# Altium schematic sheet gps.SchDoc(gps)
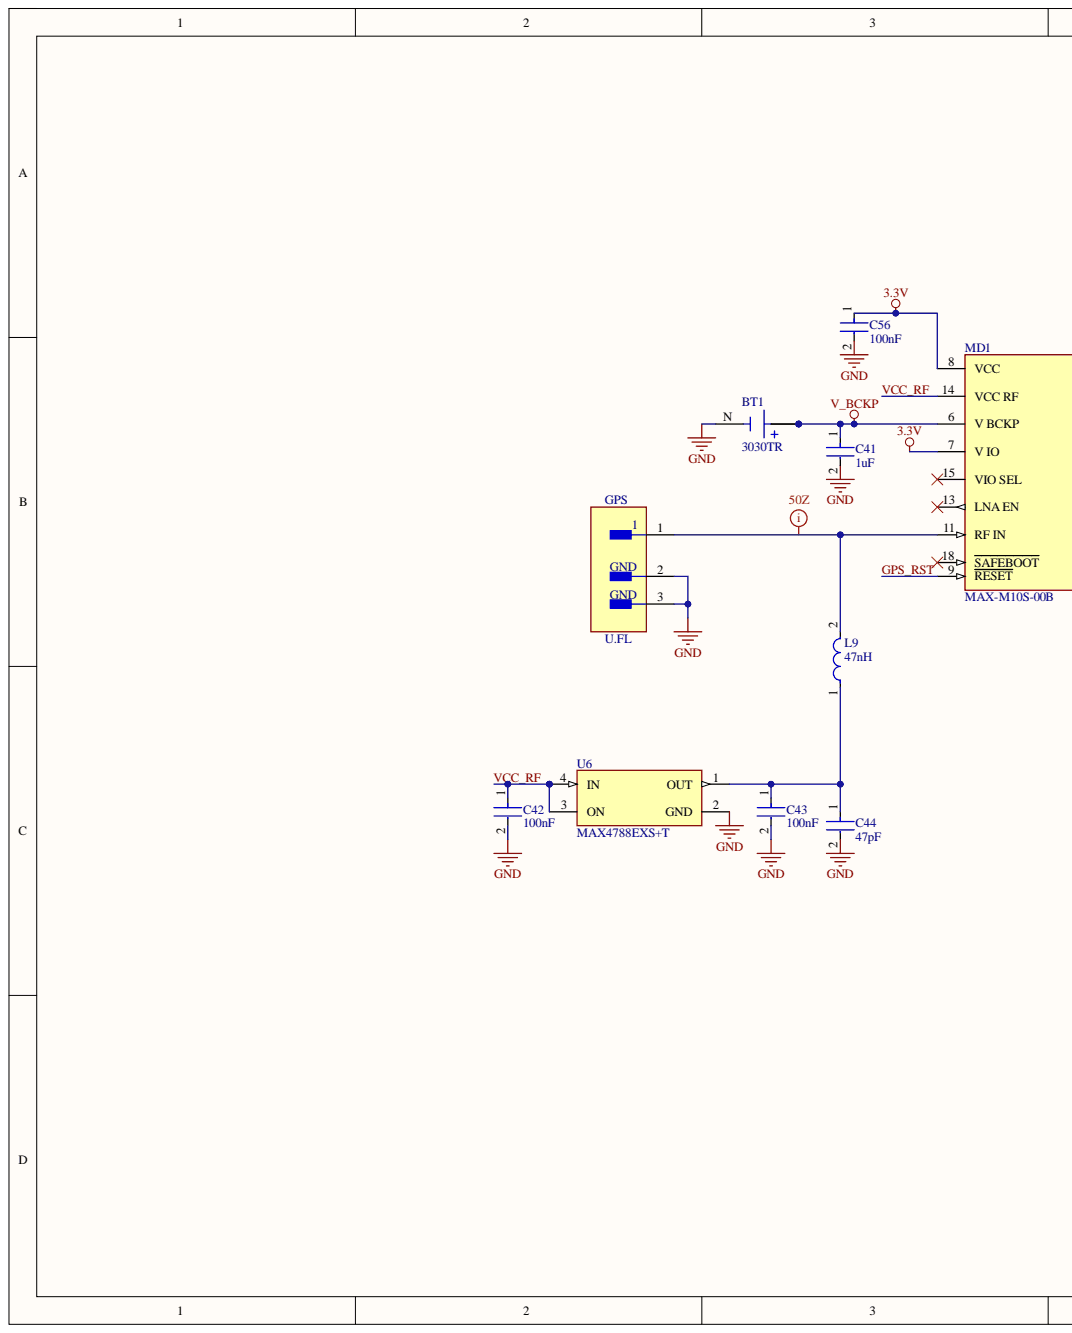
[diagram p0: gps.SchDoc, left]
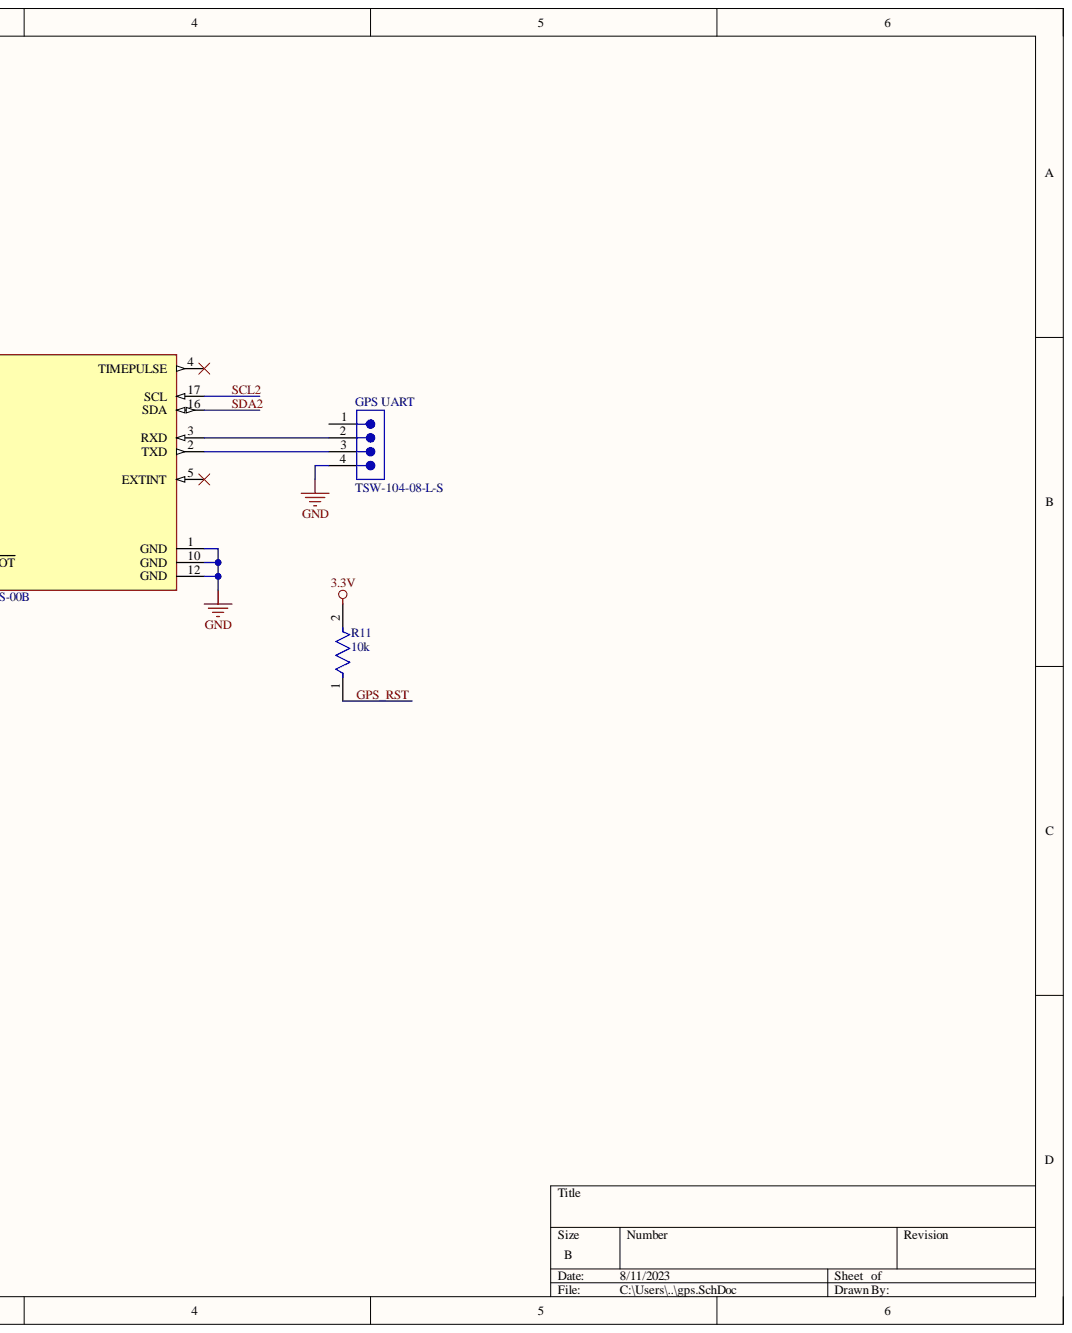
[diagram p1: gps.SchDoc, right]

## Parts
BT1  3030TR  [BAT_3030TR]
C41  1uF  [FP-C0805C105M5RACTU-MFG]
C42  100nF  [FP-C0603C-CF-MFG]
C43  100nF  [FP-C0603C-CF-MFG]
C44  47pF  [FP-0603-L_1_6_0_15-W_0_81-MFG]
C56  100nF  [FP-C0603C-CF-MFG]
GPS  U.FL  [FP-1909763-1-MFG]
GPS_UART  TSW-104-08-L-S  [SMTC-TSW-104-08-X-S]
L9  47nH  [FP-LQW18AN_00-MFG]
MD1  MAX-M10S-00B  [FP-MAX-M10S-00B-MFG]
R11  10k  [FP-RT0603-MFG]
U6  MAX4788EXS+T  [FP-X4-1-MFG]

## Nets
3.3V: C56.1 MD1.7(V_IO) MD1.8(VCC) R11.2
GND: BT1.N C41.2 C42.2 C43.2 C44.2 C56.2 GPS.2 GPS.3 GPS_UART.4 MD1.1(GND) MD1.10(GND) MD1.12(GND) U6.2(GND)
V_BCKP: BT1.P1 BT1.P2 C41.1 MD1.6(V_BCKP)
GPS_RST: MD1.9(RESET) R11.1
SCL2: MD1.17(SCL)
SDA2: MD1.16(SDA)
VCC_RF: C42.1 MD1.14(VCC_RF) U6.3(ON) U6.4(IN)
NetC43_1: C43.1 C44.1 L9.1 U6.1(OUT)
NetGPS UART_2: GPS_UART.2 MD1.3(RXD)
NetGPS UART_3: GPS_UART.3 MD1.2(TXD)
NetGPS_1: GPS.1 L9.2 MD1.11(RF_IN)

Not connected: GPS_UART 1; MD1 4(TIMEPULSE) 5(EXTINT) 13(LNA_EN) 15(VIO_SEL) 18(SAFEBOOT)

## Library data of the parts
BT1 = CMP-025-000000-1: Availability: Unavailable; Component Kind: Standard; Component Type: Standard; Design Item ID: 3030TR; MP: 3030TR; Package: None; Pin Count: 3; Price: None; Source: 3030TR.SchLib; Library Name: Purdue Space Program - High Altitude
C41 = CMP-2007-01203-2: CAP CER 1UF 50V X7R 0805; Dielectric Material: Ceramic; Dissipation Factor: 3%; Tolerance: 20%; Capacitance: 1uF; Case Code (Imperial): 0805; Case Code (Metric): 2012; Case/Package: 0805; Component Kind: Standard; Dielectric: X7R; Length: 0.079inch; Library Name: Altium Content Vault; Max Operating Temperature: 125°C; Min Operating Temperature: -55°C; Mount: Surface Mount; Package Quantity: 1; Packaging: Tape and Reel; RoHS Compliant: Yes; Series: C; Termination: SMD/SMT; Thickness: 0.055inch; Voltage Rating: 50V; Voltage Rating (DC): 50V; Width: 0.049inch
C42 C43 C56 = CMP-2006-03061-2: CAP CER 0.1UF 50V X7R 0603; Manufacturer: KEMET; Manufacturer Part Number: C0603C104K5RACTU; Automotive Grade: Grade 1; Capacitance: 0.10 μF; Material: Ceramic; Temperature Coefficient: 30ppm/°C; Tolerance: 0.1; Value: 0.10 μF; Automotive: Yes; Category: Cap; Component Kind: Standard; ComponentLink2Description: Datasheet; ComponentLink2URL: https://api.kemet.com/component-edge/download/datasheet/C0603C100J5GACAUTO.pdf; Device Class L1: Passive Components; Device Class L2: Capacitors; Device Class L3: Ceramic Capacitors; Digikey Description: CAP CER 0.1UF 50V X7R 0603; Height: 0.87mm; Lead Free: Yes; Library Name: Altium Content Vault; Octopart: https://octopart.com/c0603c104k5ractu-kemet-139244; Package: C0603C-CF; RoHS: TRUE; Temperature: -55°C to +125°C; Temperature Characteristic: X7R; Temperature Range High: +125°C; Temperature Range Low: -55°C; Voltage Rating: 50 V
C44 = CMP-2006-04316-2: General Purpose Ceramic Capacitor, 0603, 47pF, 5%, NP0, 30ppm/°C, 50V; Dielectric: C0G; Capacitance: 47pF; Case Code (Imperial): 0603; Case Code (Metric): 1608; Case/Package: 0603; Component Kind: Standard; Contact Plating: Tin; Depth: 0.81mm; Height: 0.9mm; Lead Free: Lead Free; Length: 1.6mm; Library Name: Altium Content Vault; Max Operating Temperature: 125°C; Min Operating Temperature: -55°C; Mount: Surface Mount; Number of Pins: 2; Package Quantity: 1; Packaging: Tape and Reel; Radiation Hardening: No; REACH SVHC: No SVHC; RoHS Compliant: Yes; Temperature Coefficient: 30ppm/°C; Termination: SMD/SMT; Thickness: 0.035inch; Tolerance: 5%; Voltage: 50V; Voltage Rating: 50V; Voltage Rating (DC): 50V; Width: 0.032inch
GPS = CMP-2000-07505-2: CONN UMCC JACK STR 50OHM SMD; Body Material: Polymer; Body Plating: Gold; Connector System: Cable-to-Board; Frequency: 6GHz; Gender: Female; Impedance: 50R; Insertion Loss: 0.24dB; Mating Cycles: 30; Number of Ports: 1; Sealable: No (Without); Voltage Rating: 60V; Case/Package: SMD/SMT; Center Contact Plating: Gold; Component Kind: Standard; Connector Type: Jack; Contact Material: Copper Alloy; Contact Plating: Gold; Dielectric Material: Polymer; ELV: Compliant; Fastening Type: SNAP-ON; Housing Color: Beige; Insulation Material: Thermoplastic; Lead Free: Lead Free; Length: 3mm; Library Name: Altium Content Vault; Max Frequency: 6GHz; Max Operating Temperature: 194°C; Min Operating Temperature: -40°C; Mount: Panel; Number of Positions: 1; Orientation: Straight; Package Quantity: 1; Packaging: Tape and Reel; Radiation Hardening: No; RoHS Compliant: Yes; Series: -; Termination: SMD/SMT
GPS_UART = CMP-1024-00358-1: 0.025" SQ Post Header, Through-hole, Vertical, -55 to 125 degC, 2.54 mm Pitch, 4-Pin, Male, RoHS; Manufacturer: Samtec; Pitch: 2.54 mm; Component Kind: Standard; ComponentLink1Description: Manufacturer URL; ComponentLink1URL: http://www.samtec.com; ComponentLink2Description: Datasheet; ComponentLink2URL: http://www.samtec.com/documents/webfiles/pdf/TSW_TH.PDF; ComponentLink3Description: Package Specification; ComponentLink3URL: http://www.samtec.com/documents/webfiles/cpdf/TSW-XXX-XX-XXX-X-XX-XXX-MKT.pdf; DatasheetVersion: F-214; Library Name: Altium Content Vault; Mounting Technology: Through-hole; Orientation: Vertical; PackageDescription: .025[0.64] SQ. Post Terminal Strip Assembly; PackageReference: TSW-104-08-X-S; PackageVersion: DM, 8/1988; PartNumber: TSW-104-08-L-S; RoHS: True; Temperature Range: -55 to 125 degC
L9 = CMP-06042-007966-1: Wire Wound RF Inductor 47nH ±2% 380mA 0.29Ω 0603 (1608); Core Material: Non-Magnetic; Current: 340mA; DC Resistance (DCR): 290mR; Q Factor: 38; Self Resonant Frequency: 2.6GHz; Shielding: Unshielded; Test Frequency: 100MHz; Case Code (Imperial): 0603; Case Code (Metric): 1608; Case/Package: 0603; Component Kind: Standard; Current Rating: 380mA; DC Current: 380mA; Depth: 1mm; Height: 0.8mm; Height - Seated (Max): 0.039inch; Inductance: 47nH; Inductance Tolerance: 2%; Lead Free: Lead Free; Length: 1.6mm; Library Name: Altium Content Vault; Max DC Current: 380mA; Max Operating Temperature: 125°C; Military Standard: Not; Min Operating Temperature: -55°C; Mount: Surface Mount; Number of Pins: 2; Package Quantity: 4000; Packaging: Tape and Reel; Radiation Hardening: No; REACH SVHC: No SVHC; Resistor Type: Wirewound; Series Resistance: 290mR; Termination: SMD/SMT; Tolerance: 2%; Weight: 0.000106oz; Width: 0.8mm
MD1 = CMP-207130-000026-1: GNSS LCC RX, ROM, TCXO, LNA, SAW; Component Kind: Standard; Library Name: Altium Content Vault
R11 = CMP-2000-04517-2: RES SMD 10K OHM 0.1% 1/10W 0603; Manufacturer: Yageo; Manufacturer Part Number: RT0603BRE0710KL; Power Rating: 0.1W; Resistance: 10KΩ; Temperature Coefficient: 50 ppm/°C; Tolerance: 0.001; Value: 10KΩ; Voltage Rating: 75V; Automotive: No; Category: Res; Component Kind: Standard; ComponentLink2Description: Datasheet; ComponentLink2URL: http://www.yageo.com/documents/recent/PYu-RT_1-to-0.01_RoHS_L_9.pdf; Composition: Thin Film; Device Class L1: Passive Components; Device Class L2: Resistors; Device Class L3: Chip SMD Resistors; Digikey Description: RES SMD 10K OHM 0.1% 1/10W 0603; Footprint Url: http://www.yageo.com/exep/pages/download/literatures/PYu-R_Mount_10.pdf; Height: 0.55mm; Ipc Land Pattern Name: RESC16080X45; Lead Free: Yes; Library Name: Altium Content Vault; Mouser Description: Res Thin Film 0603 10K Ohm 0.1% 1/10W ±50ppm/°C Molded SMD SMD Paper T/R; Octopart: https://octopart.com/rt0603bre0710kl-yageo-1273959; Package: RT0603; RoHS: TRUE; Series: RT; Temperature: -55°C to +155°C; Temperature Range High: +155°C; Temperature Range Low: -55°C
U6 = CMP-05714-000107-1: IC SWITCH 1X1 SC70-4; Max Input Voltage: 5.5V; Max Output Current: 240mA; Max Power Dissipation: 245mW; Min Input Voltage: 2.3V; Number of Inputs: 1; On-State Resistance: 1R; Operating Supply Current: 65uA; Supply Type: Single; Turn-Off Delay Time: 50us; Turn-On Delay Time: 30ns; Case/Package: SC; Component Kind: Standard; Contact Plating: Tin; Library Name: Altium Content Vault; Max Operating Temperature: 85°C; Min Operating Temperature: -40°C; Mount: Surface Mount; Number of Outputs: 1; Number of Pins: 4; Operating Supply Voltage: 5.5V; Package Quantity: 1; Packaging: Tape and Reel; Radiation Hardening: No; RoHS Compliant: Yes; Series: -
